# Altium schematic sheet Power.SchDoc
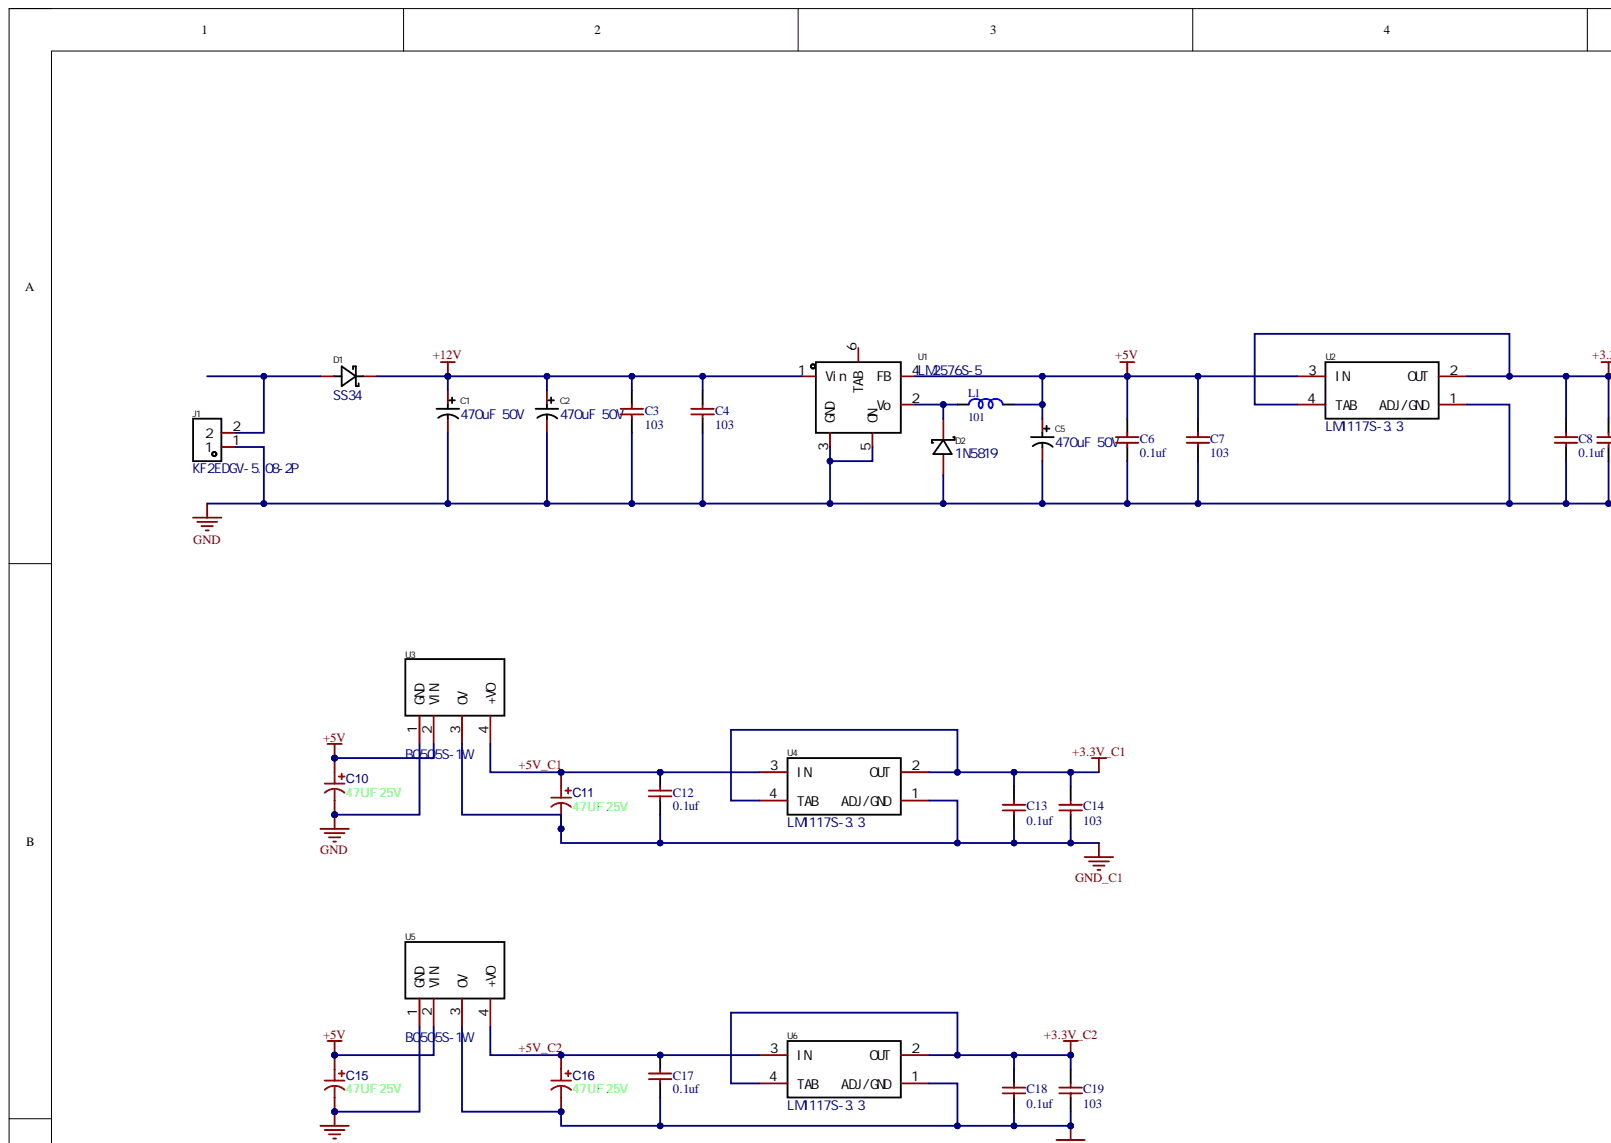
[diagram p0: Power.SchDoc, top left]
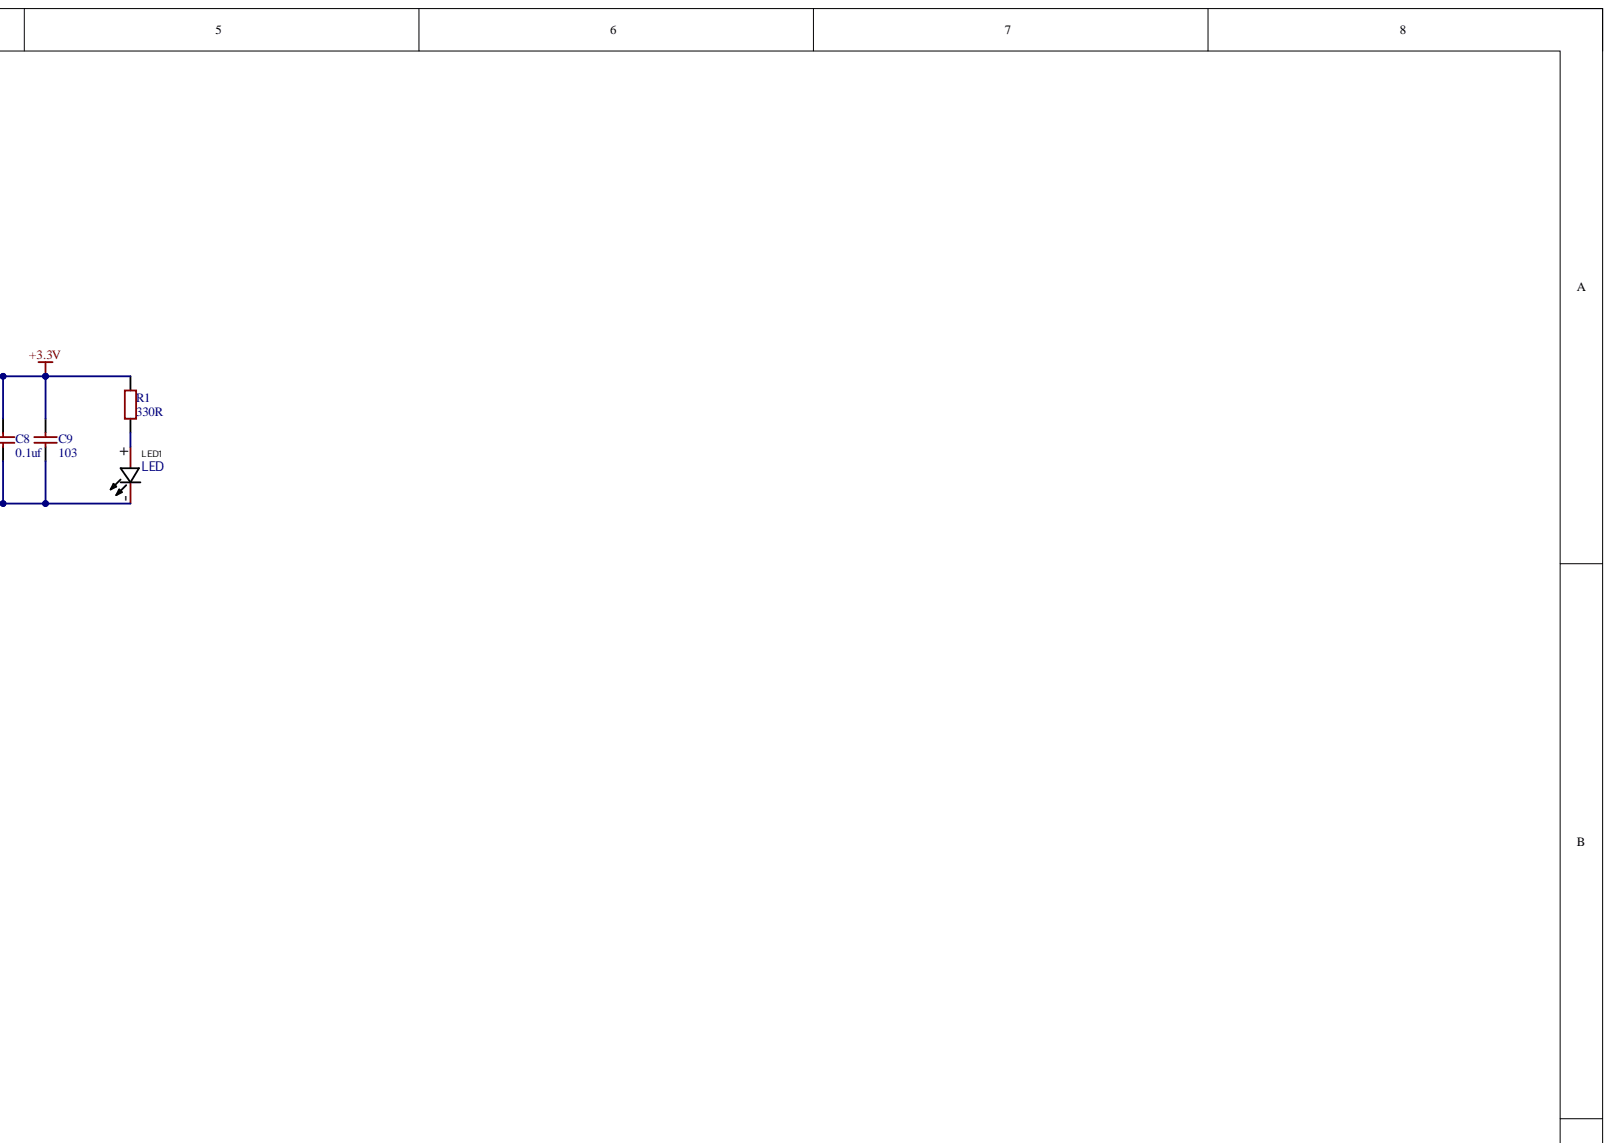
[diagram p1: Power.SchDoc, top right]
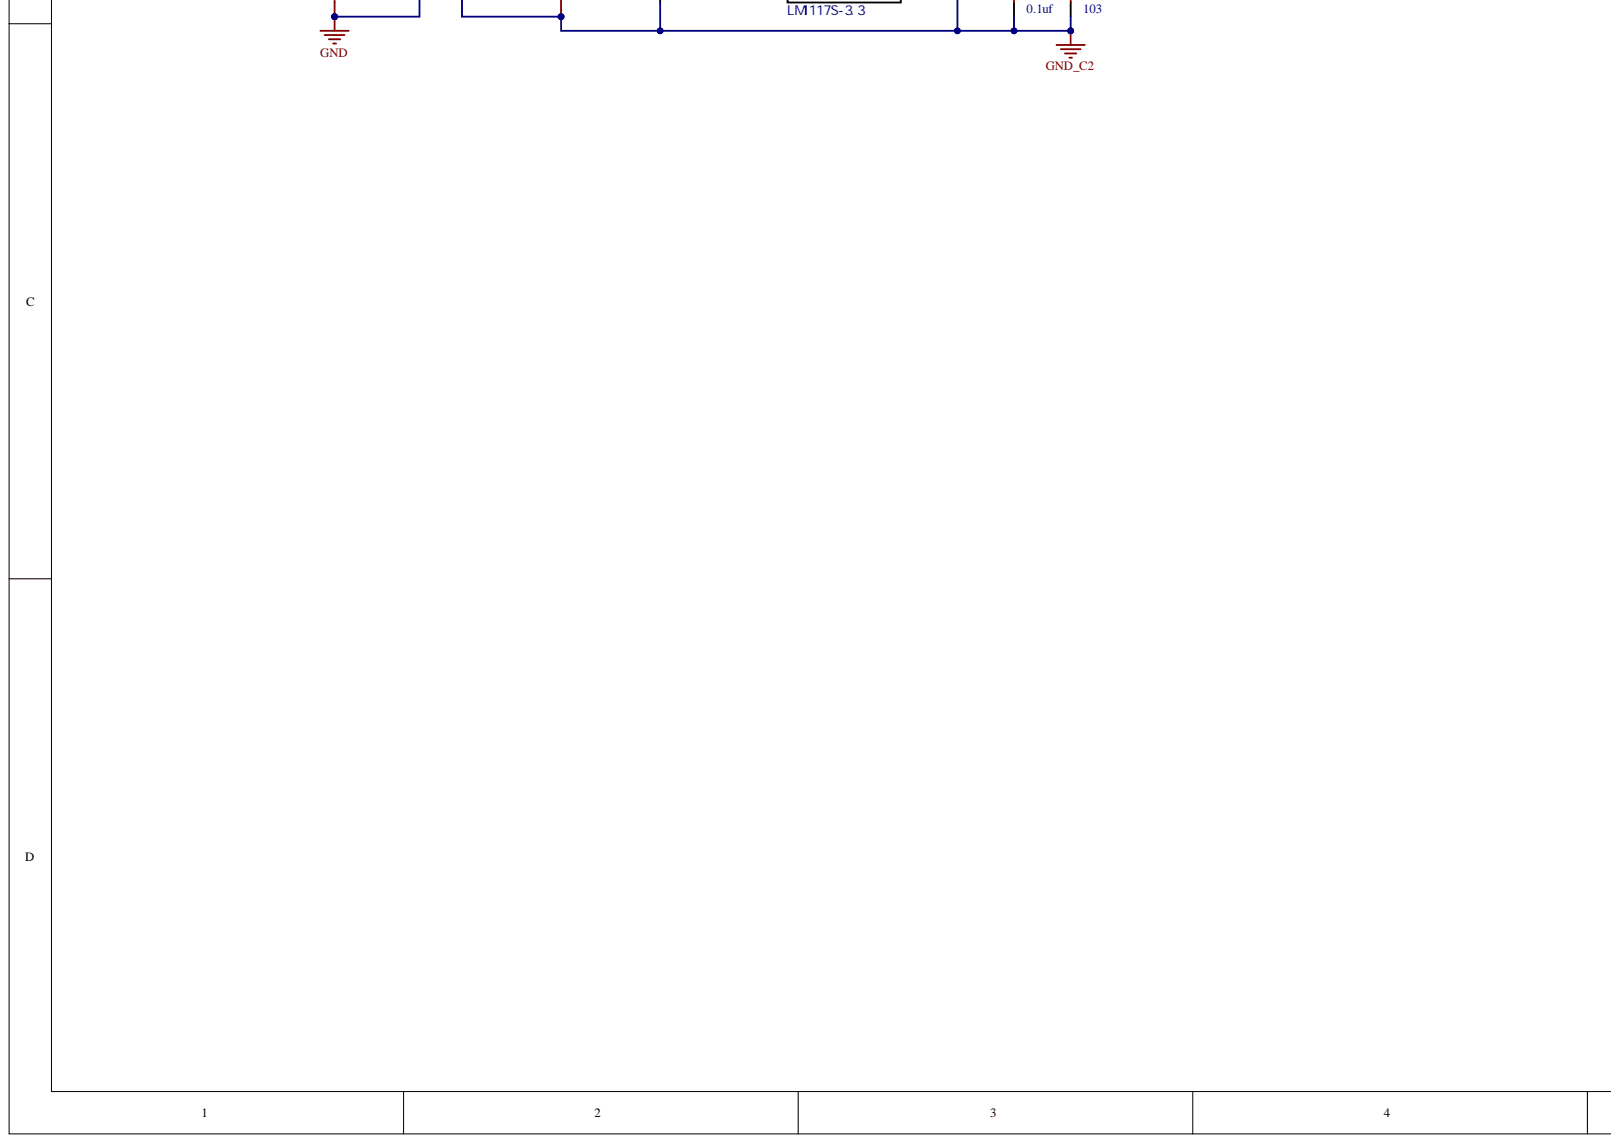
[diagram p2: Power.SchDoc, bottom left]
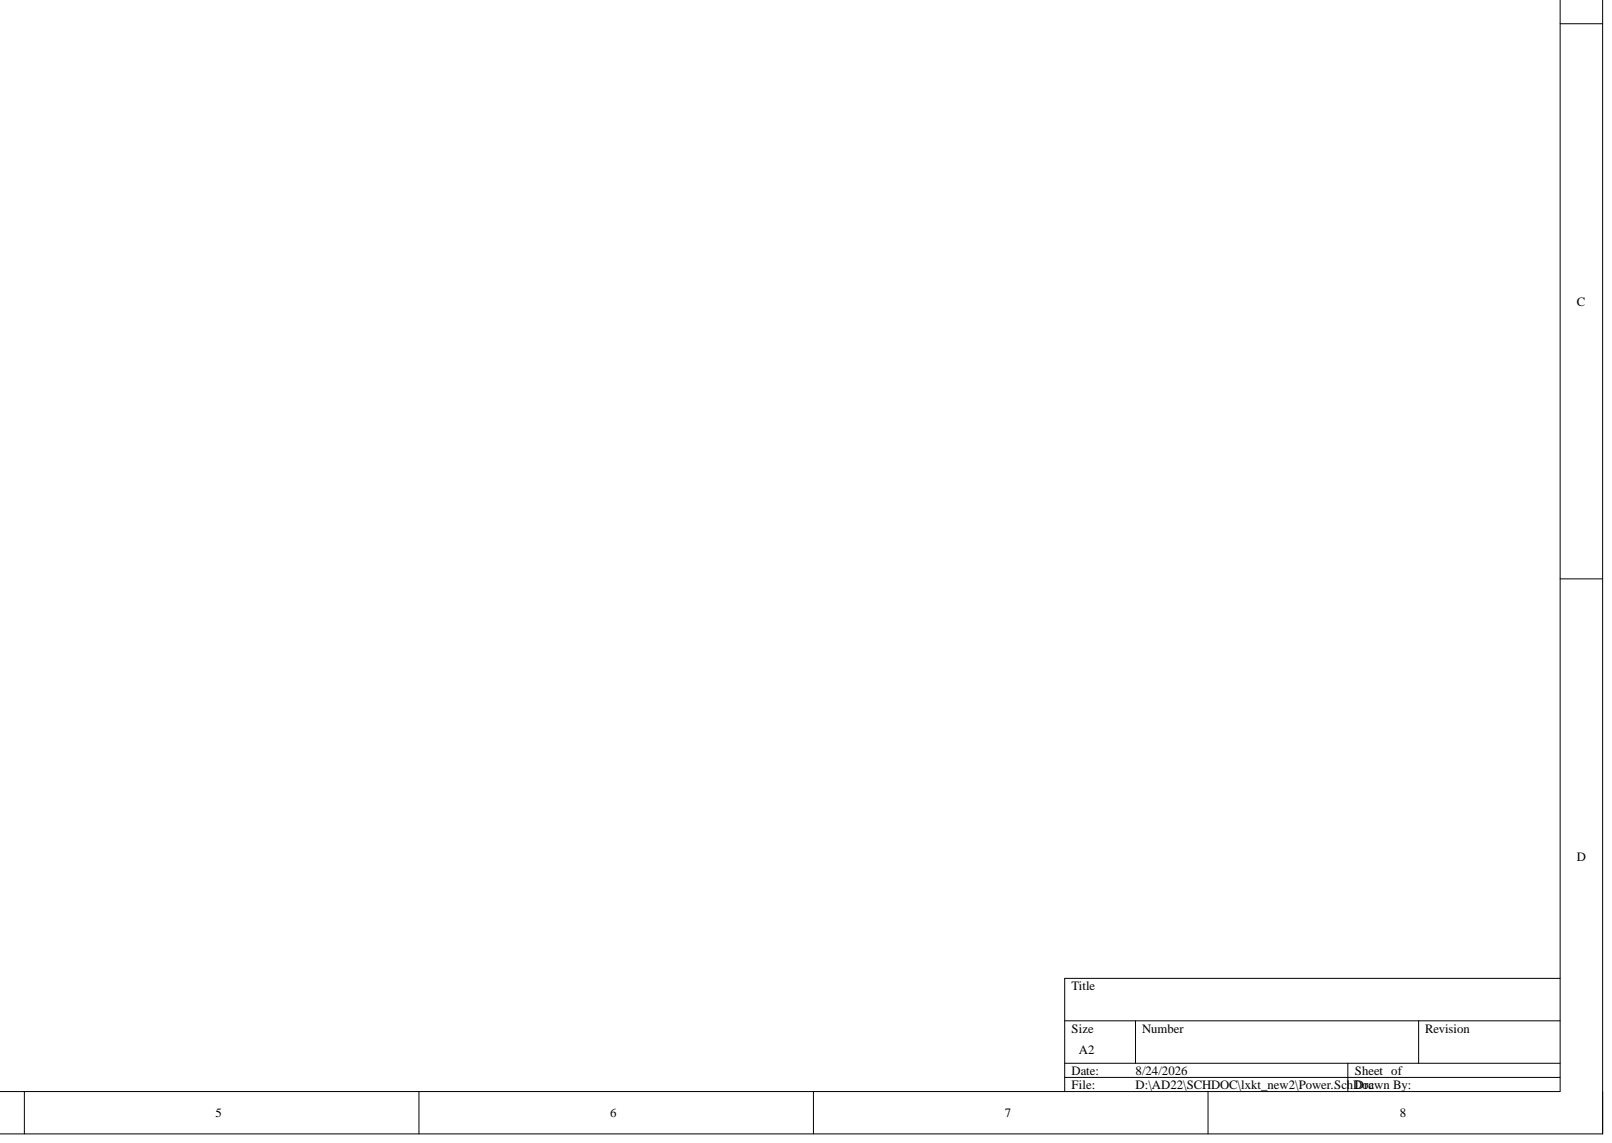
[diagram p3: Power.SchDoc, bottom right]

## Parts
C1  470uF 50V  value 470uF  Name: 470uF  [CAP-TH_BD10.0-P5.00-D1.0-FD]
C2  470uF 50V  value 470uF  Name: 470uF  Rated Voltage: 50V  [CAP-TH_BD10.0-P5.00-D1.0-FD]
C3  103  [0805_C]
C4  103  [0805_C]
C5  470uF 50V  value 470uF  Name: 470uF  [CAP-TH_BD10.0-P5.00-D1.0-FD]
C6  0.1uf  [0805_C]
C7  103  [0805_C]
C8  0.1uf  [0805_C]
C9  103  [0805_C]
C10  47UF 25V  Voltage Rating: 25V  [CAP-TH_BD6.3-P2.50-D0.5-FD]
C11  47UF 25V  Voltage Rating: 25V  [CAP-TH_BD6.3-P2.50-D0.5-FD]
C12  0.1uf  [0805_C]
C13  0.1uf  [0805_C]
C14  103  [0805_C]
C15  47UF 25V  Voltage Rating: 25V  [CAP-TH_BD6.3-P2.50-D0.5-FD]
C16  47UF 25V  Voltage Rating: 25V  [CAP-TH_BD6.3-P2.50-D0.5-FD]
C17  0.1uf  [0805_C]
C18  0.1uf  [0805_C]
C19  103  [0805_C]
D1  SS34  [SMA_L4.3-W2.5-LS5.0-RD]
D2  1N5819  [SMA_L4.3-W2.5-LS5.0-RD]
J1  KF2EDGV-5.08-2P  [CONN-TH_P5.08_KF2EDGV-5.08-2P]
L1  101  [L1]
LED1  LED  [LED-SMD_L2.0-W1.3-R-RD_RED]
R1  330R  [0805_R]
U1  LM2576S-5  [TO-263-5_L10.2-W9.9-P1.70-LS14.4-TL]
U2  LM1117S-3.3  [SOT-223-3_L6.5-W3.4-P2.30-LS7.0-BR]
U3  B0505S-1W  [PWRM-TH_MORNSUN_B0524M-2WR3]
U4  LM1117S-3.3  [SOT-223-3_L6.5-W3.4-P2.30-LS7.0-BR]
U5  B0505S-1W  [PWRM-TH_MORNSUN_B0524M-2WR3]
U6  LM1117S-3.3  [SOT-223-3_L6.5-W3.4-P2.30-LS7.0-BR]

## Nets
+3.3V: C8.1 C9.1 R1.2 U2.2(OUT) U2.4(TAB)
+3.3V_C1: C13.1 C14.1 U4.2(OUT) U4.4(TAB)
+3.3V_C2: C18.1 C19.1 U6.2(OUT) U6.4(TAB)
+5V: C5.1 C6.1 C7.1 C10.1 C15.1 L1.2 U1.4(FB) U2.3(IN) U3.2(VIN) U5.2(VIN)
+12V: C1.1 C2.1 C3.1 C4.1 D1.1 U1.1(Vin)
GND: C1.2 C2.2 C3.2 C4.2 C5.2 C6.2 C7.2 C8.2 C9.2 C10.2 C15.2 D2.2 J1.1 LED1.2 U1.3(GND) U1.5(ON) U2.1(ADJ/GND) U3.1(GND) U5.1(GND)
GND_C1: C11.2 C12.1 C13.2 C14.2 U3.3(0V) U4.1(ADJ/GND)
GND_C2: C16.2 C17.1 C18.2 C19.2 U5.3(0V) U6.1(ADJ/GND)
+5V_C1: C11.1 C12.2 U3.4(+VO) U4.3(IN)
+5V_C2: C16.1 C17.2 U5.4(+VO) U6.3(IN)
NetD1_2: D1.2 J1.2
NetD2_1: D2.1 L1.1 U1.2(Vo)
NetLED1_1: LED1.1 R1.1

Not connected: U1 6(TAB)

## Library data of the parts
C1 C2 C5 = 470uF ±20% 50V: 直插铝电解电容P=5.0; Manufacturer: CX(承兴); Add into BOM: yes; Device: KM477M050G20RR0VH2FP0; L: 20mm; Lifetime @ Temp: 2000hrs@105℃; Tolerance: ±20%; φD: 10mm; Component Kind: Standard; Convert to PCB: yes; Datasheet: https://atta.szlcsc.com/upload/public/pdf/source/20211027/06D6BA3719C264B639DC286D935D03EA.pdf; JLCPCB Part Class: Extended Part; LCSC Part Name: 470uF ±20% 50V; Lead Spacing: 5mm; Manufacturer Part: KM477M050G20RR0VH2FP0; Supplier: LCSC; Supplier Footprint: 直插,D10xL20mm; Supplier Part: C34642; Symbol: 470UF 50V; Library Name: fenrong0303_Library.IntLib
  C1 C5: Rated Voltage: 50V
C3 C4 C6-C9 C12-C14 C17-C19 = C 0603 1.0pF (010) 0.25pF 50V: 贴片电容; Component Kind: Standard; ComponentLink1Description: 供应商链接; ComponentLink1URL: http://www.szlcsc.com/product/details_24709.html; Library Name: BasicComponents2205.IntLib; Package: 0603; Supplier: LC; SuppliersPartNumber: C23969
C10 C11 C15 C16 = 47UF 25V: 直插铝电解电容 D6.3xL11mm; Manufacturer: JIERR(捷而瑞); ManufacturerPart: ERG25V220M6X11; AddInBom: yes; Equivalent Series Resistance(ESR): 130mΩ@100kHz; Lifetime: 2000hrs@105℃; ModelId: 983aa343eddc42b59ebb10541a88166e; ModelTransform: 247.99486,248.229,0,90,0,0,0,0,-196.85; Pin Spacing: 2.5mm; Ripple Current: 510mA@100kHz; Tolerance: ±20%; Value: 220uF; Component Kind: Standard; ConvertToPcb: yes; Datasheet: https://item.szlcsc.com/datasheet/ERG25V220M6X11/49556618.html; Diameter: 6mm; FootprintName: CAP-TH_BD6.3-P2.50-D0.5-FD; Height - Seated (Max): 11mm; JLCPCB Part Class: Extended Part; LCSC Part Name: 220uF ±20% 25V; ModelName: CAP-TH_BD6.3-P2.50-D0.5-FD; Operating Temperature: -40℃~+105℃; Supplier: LCSC; Supplier Footprint: 插件,D6.3xL11mm; SupplierPart: C47344177; Symbol: ERG25V220M6X11; Library Name: lxkt.IntLib
D1 = SS34: 肖特基二极管; Manufacturer: TWGMC(台湾迪嘉); Add into BOM: yes; Average Rectified Current (Io): 3A; Device: SS34_C727060; Diode Configuration: 独立式; Forward Voltage (Vf@If): 550mV@3A; Reverse Leakage Current (Ir): 500uA@40V; Reverse Voltage (Vr): 40V; Component Kind: Standard; Convert to PCB: yes; Datasheet: https://atta.szlcsc.com/upload/public/pdf/source/20200828/C727059_6599B6CAC5F3238B9C33E46794659DBE.pdf; JLCPCB Part Class: Extended Part; LCSC Part Name: 电压:40V 电流:3A; Manufacturer Part: SS34; Supplier: LCSC; Supplier Footprint: SMA(DO-214AC); Supplier Part: C727060; Symbol: SS34_C727060; Library Name: lxkt.IntLib
D2 = 1N5819: 肖特基二极管; Manufacturer: KEXIN(科信); Add into BOM: yes; Average Rectified Current (Io): 1A; Device: 1N5819_C437199; Forward Voltage (Vf@If): 500mV@1A; Reverse Voltage (Vr): 40V; Component Kind: Standard; Convert to PCB: yes; Datasheet: https://atta.szlcsc.com/upload/public/pdf/source/20191012/C437199_83583E622A6684E767605E43C169C596.pdf; JLCPCB Part Class: Extended Part; LCSC Part Name: 40V 1A 500mV@1A; Manufacturer Part: 1N5819; Name: 1N5819; Supplier: LCSC; Supplier Footprint: SMA; Supplier Part: C437199; Symbol: 1N5819_C437199; Library Name: WXcharge0210.IntLib
J1 = KF2EDGV-5.08-2P: 插拔式接线端子5.08; Manufacturer: KEFA(科发); Add into BOM: yes; Connector Type: 板端/插座-开口; Current Rating (Max): 15A; Number of PINs Per Row: 2; Pitch: 5.08mm; Voltage Rating (Max): 300V; Color: 绿色; Component Kind: Standard; Convert to PCB: yes; Datasheet: https://atta.szlcsc.com/upload/public/pdf/source/20191023/C441354_3C7B25AC1BDF5D3928A713B39DBA0745.pdf; Device: KF2EDGV-5.08-2P; JLCPCB Part Class: Extended Part; LCSC Part Name: 板端/插座-开口 5.08mm 排数:1 每排P数:2 直针; Manufacturer Part: KF2EDGV-5.08-2P; Mounting Style: 直针; Name: KF2EDGV-5.08-2P; Number of Rows: 1; Operating Temperature Range: -40℃~+105℃; Supplier: LCSC; Supplier Footprint: 插件,P=5.08mm; Supplier Part: C441354; Symbol: KF2EDGV-5.08-2P; Library Name: fenrong0303_Library.IntLib
L1 = INDUCTOR1: 功率电感; Component Kind: Standard; Library Name: WXcharge0210.IntLib
LED1 = LED: 发光二极管; Manufacturer: AVAGO(安华高); Add into BOM: yes; Device: HSMH-C170; Dominant Wavelength: 639nm; Emitted Color: 红灯; Forward Current: 20mA; Peak Wavelength: 660nm; Power Dissipation: 65mW; Viewing Angle: 170°; Color Temperature: -; Component Kind: Standard; Convert to PCB: yes; Datasheet: https://atta.szlcsc.com/upload/public/pdf/source/20180327/C188731_AE456C2A02072991650BCB05E3278EDF.pdf; Diode Configuration: -; JLCPCB Part Class: Extended Part; LCSC Part Name: 红灯 639nm; Lens Color: -; Manufacturer Part: HSMH-C170; Mounting Sytle: -; Name: HSMH-C170; Operating Temperature: -40℃~+85℃; Supplier: LCSC; Supplier Footprint: 0805; Supplier Part: C188731; Symbol: HSMH-C170; Library Name: WXcharge0210.IntLib
R1 = R 0603 0Ω (0R0) 1%: 贴片电阻; Component Kind: Standard; ComponentLink1Description: 供应商链接; ComponentLink1URL: http://www.szlcsc.com/product/details_21903.html; Library Name: BasicComponents2205.IntLib; Package: 0603; Supplier: LC; SuppliersPartNumber: C21189
U1 = LM2576S-5: DC-DC; Manufacturer: HTC; Add into BOM: yes; Device: LM2576R-12; Function: 降压型; Component Kind: Standard; Convert to PCB: yes; Datasheet: https://atta.szlcsc.com/upload/public/pdf/source/20161226/1482720162554.pdf; Input Voltage: -; JLCPCB Part Class: Extended Part; LCSC Part Name: 降压型; Manufacturer Part: LM2576R-12; Name: LM2576R-12; Number of Outputs: -; Output Current (Max): -; Supplier: LCSC; Supplier Footprint: TO-263-5; Supplier Part: C91094; Switching Frequency: -; Symbol: LM2576R-12; Synchronous Rectification: -; Topology: -; Library Name: WXcharge0210.IntLib
U2 U4 U6 = LM1117S-3.3: LDO; Manufacturer: HTC; Add into BOM: yes; Dropout Voltage: 1.3V@(1A); Maximum Input Voltage: 12V; Number of Outputs: 1; Output Current: 1A; Output Polarity: 正; Output Type: 固定; Output Voltage: 3.3V; Power Supply Rejection Ratio (PSRR): 75dB@(120Hz); Component Kind: Standard; Convert to PCB: yes; Datasheet: https://atta.szlcsc.com/upload/public/pdf/source/20170814/C126027_1502678373551885899.pdf; Device: LM1117S-3.3; JLCPCB Part Class: Extended Part; LCSC Part Name: 正 Vin=12V Vout=3.3V 1A 75dB@(120Hz); Manufacturer Part: LM1117S-3.3; Name: LM1117S-3.3; Supplier: LCSC; Supplier Footprint: SOT-223; Supplier Part: C126027; Symbol: LM1117S-3.3; Library Name: WXcharge0210.IntLib
U3 U5 = B0512M-2WR3: 电源模块; Manufacturer: MORNSUN(金升阳); Add into BOM: yes; Conversion Efficiency: 81%; Input Voltage(DC): 4.5V~5.5V; Insulated Voltage: 1.5kV; Output Channel: 1; Output Current (Max): 167mA; Output Power: 2W; Output Voltage: 12V; Component Kind: Standard; Convert to PCB: yes; Datasheet: https://atta.szlcsc.com/upload/public/pdf/source/20230221/9FA4977A77FF4947CAF4BFA1DA71CB83.pdf; Device: B0512M-2WR3; LCSC Part Name: B0512M-2WR3; Library Name: pro24.IntLib; Manufacturer Part: B0512M-2WR3; Name: B0512M-2WR3; Supplier: LCSC; Supplier Footprint: SIP-4; Supplier Part: C6705092; Symbol: B0512M-2WR3
